# Altium schematic sheet Sheet1.SchDoc(Sheet1)
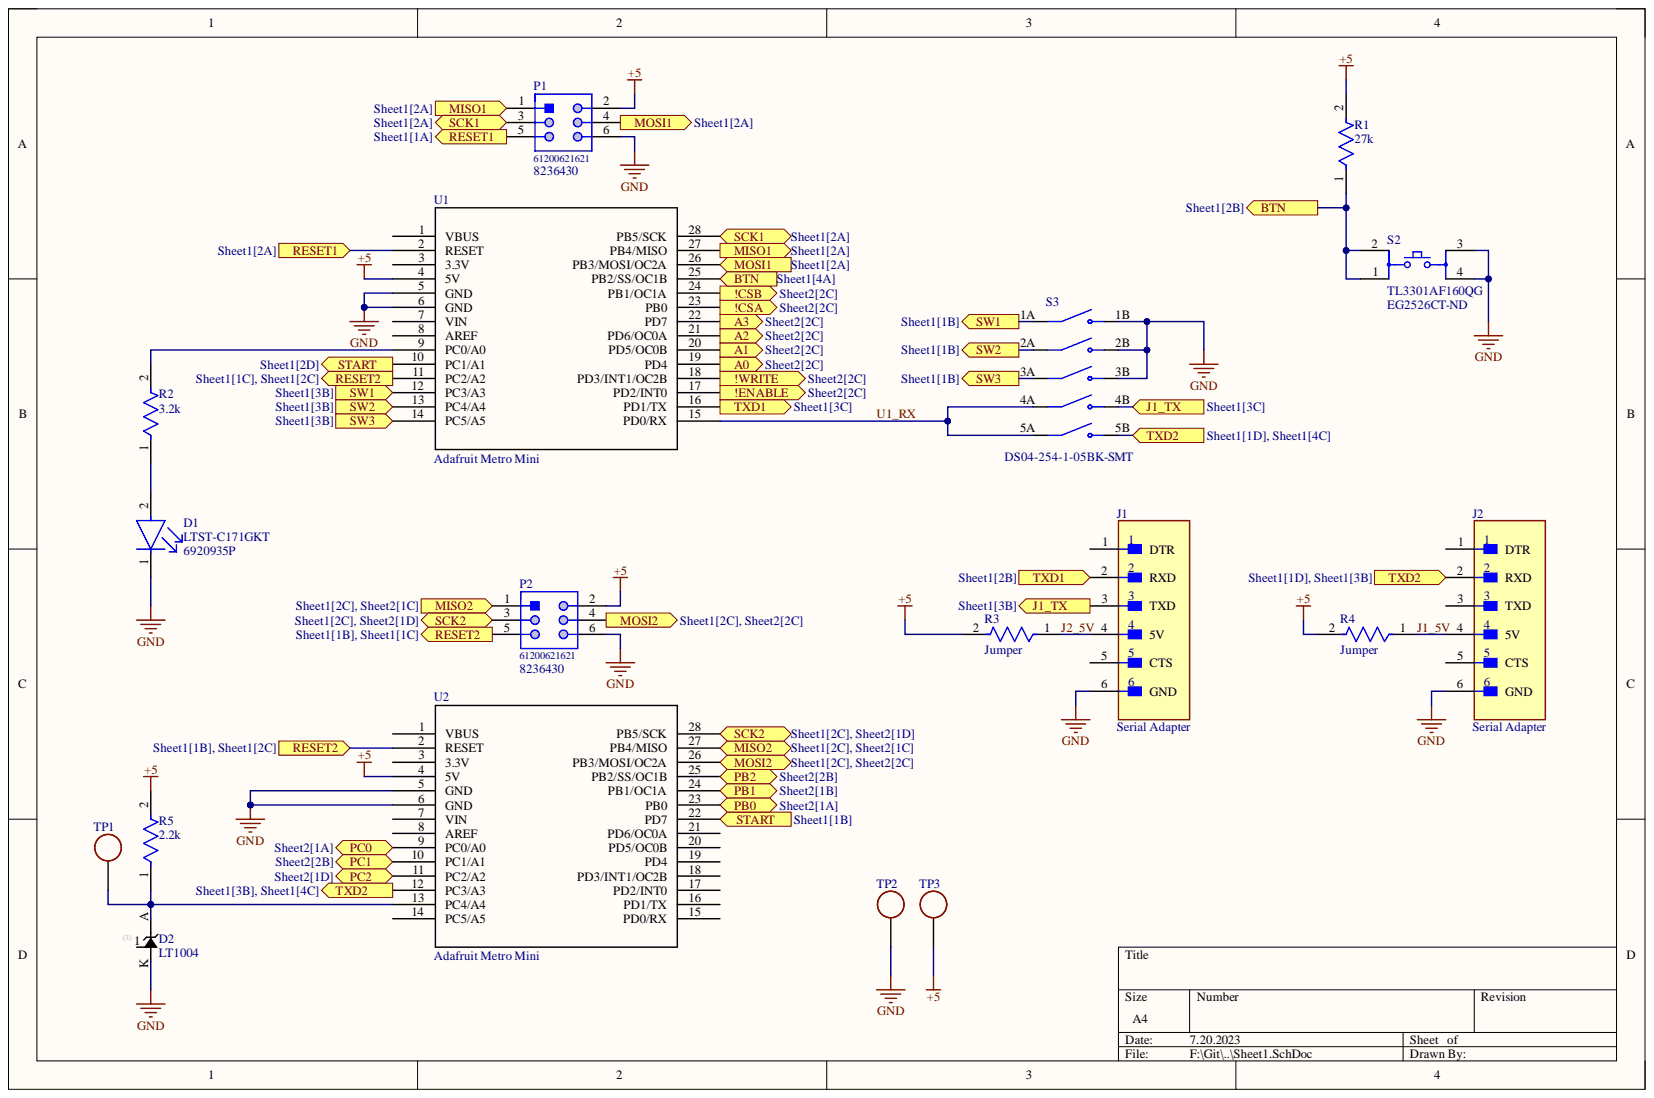
[diagram p0: Sheet1.SchDoc]

## Parts
D1  LTST-C171GKT  Supplier Part Number 1: 6920935P  [FP-LTST-C171GKT-MFG]
D2  LT1004  [LT1004CZ]
J1  Serial Adapter  [FP-22-28-4060-MFG]
J2  Serial Adapter  [FP-22-28-4060-MFG]
P1  61200621621  Supplier Part Number 1: 8236430  [61200621621]
P2  61200621621  Supplier Part Number 1: 8236430  [61200621621]
R1  27k  [FP-RMCF0805-IPC_B]
R2  3.2k  [FP-RMCF0805-IPC_B]
R3  Jumper  [FP-RMCF0805-IPC_B]
R4  Jumper  [FP-RMCF0805-IPC_B]
R5  2.2k  [FP-RMCF0805-IPC_B]
S1  TL3301AF160QG  [FP-TL3301AF160QG-MFG]
S2  TL3301AF160QG  Supplier Part Number 1: EG2526CT-ND  [FP-TL3301AF160QG-MFG]
S3  DS04-254-1-05BK-SMT  [SW_DS04-254-1-05BK-SMT]
TP1  61300111121  [61300111121]  (value not drawn)
TP2  61300111121  [61300111121]  (value not drawn)
TP3  61300111121  [61300111121]  (value not drawn)
U1  Adafruit Metro Mini  [Adafruit Metro Mini]  (no BOM)
U2  Adafruit Metro Mini  [Adafruit Metro Mini]  (no BOM)

## Ports
!CSA, !CSB, !ENABLE, !WRITE, A0, A1, A2, A3, BTN, J1_TX, MISO1, MISO2, MOSI1, MOSI2, PB0, PB1, PB2, PC0, PC1, PC2, RESET1, RESET2, SCK1, SCK2, START, SW1, SW2, SW3, TXD1, TXD2

## Nets
+5: P1.2 P2.2 R1.2 R3.2 R4.2 R5.2 TP3.1 U1.4(5V) U2.4(5V)
GND: D1.1 D2.K J1.6 J2.6 P1.6 P2.6 S2.3 S2.4 S3.1B S3.2B S3.3B TP2.1 U1.5(GND) U1.6(GND) U2.5(GND) U2.6(GND)
!CSA: U1.23(PB0) ; port !CSA
!CSB: U1.24(PB1/OC1A) ; port !CSB
!ENABLE: U1.17(PD2/INT0) ; port !ENABLE
!WRITE: U1.18(PD3/INT1/OC2B) ; port !WRITE
A0: U1.19(PD4) ; port A0
A1: U1.20(PD5/OC0B) ; port A1
A2: U1.21(PD6/OC0A) ; port A2
A3: U1.22(PD7) ; port A3
BTN: R1.1 S2.1 S2.2 U1.25(PB2/SS/OC1B) ; port BTN
J1_5V: J2.4 R4.1
J1_TX: J1.3 S3.4B ; port J1_TX
J2_5V: J1.4 R3.1
MISO1: P1.1 U1.27(PB4/MISO) ; port MISO1
MISO2: P2.1 U2.27(PB4/MISO) ; port MISO2
MOSI1: P1.4 U1.26(PB3/MOSI/OC2A) ; port MOSI1
MOSI2: P2.4 U2.26(PB3/MOSI/OC2A) ; port MOSI2
PB0: U2.23(PB0) ; port PB0
PB1: U2.24(PB1/OC1A) ; port PB1
PB2: U2.25(PB2/SS/OC1B) ; port PB2
PC0: U2.9(PC0/A0) ; port PC0
PC1: U2.10(PC1/A1) ; port PC1
PC2: U2.11(PC2/A2) ; port PC2
RESET1: P1.5 U1.2(RESET) ; port RESET1
RESET2: P2.5 U1.11(PC2/A2) U2.2(RESET) ; port RESET2
SCK1: P1.3 U1.28(PB5/SCK) ; port SCK1
SCK2: P2.3 U2.28(PB5/SCK) ; port SCK2
START: U1.10(PC1/A1) U2.22(PD7) ; port START
SW1: S3.1A U1.12(PC3/A3) ; port SW1
SW2: S3.2A U1.13(PC4/A4) ; port SW2
SW3: S3.3A U1.14(PC5/A5) ; port SW3
TXD1: J1.2 U1.16(PD1/TX) ; port TXD1
TXD2: J2.2 S3.5B U2.12(PC3/A3) ; port TXD2
U1_RX: S3.4A S3.5A U1.15(PD0/RX)
NetD1_2: D1.2 R2.1
NetD2_A: D2.A R5.1 TP1.1 U2.13(PC4/A4)
NetR2_2: R2.2 U1.9(PC0/A0)

Not connected: D2 3; J1 1 5; J2 1 3 5; S1 1 2 3 4; U1 1(VBUS) 3(3.3V) 7(VIN) 8(AREF); U2 1(VBUS) 3(3.3V) 7(VIN) 8(AREF) 14(PC5/A5) 15(PD0/RX) 16(PD1/TX) 17(PD2/INT0) 18(PD3/INT1/OC2B) 19(PD4) 20(PD5/OC0B) 21(PD6/OC0A)

## Library data of the parts
D1 = CMP-22017-000007-1: LED GREEN CLEAR CHIP SMD; Dominant Wavelength: 569nm; Forward Current: 30mA; Forward Voltage: 2.1V; Lens Color: Colorless; Lens Style: Diffused; Luminous Intensity: 12mcd; Number of Elements: 1; Power Dissipation: 100mW; Reverse Voltage: 5V; Test Current: 10mA; Viewing Angle: 130°; Wavelength: 585nm; Case Code (Imperial): 0805; Case Code (Metric): 2012; Case/Package: 0805; Color: Green; Component Kind: Standard; Depth: 1.25mm; Height: 0.8mm; Illumination Color: Green; Lead Free: Lead Free; Length: 2mm; Lens Transparency: Clear; Max Operating Temperature: 85°C; Min Operating Temperature: -55°C; Mount: Surface Mount; Number of LEDs: 1; Number of Pins: 2; Package Quantity: 1; Packaging: Tape and Reel; Power Rating: 100mW; Radiation Hardening: No; Supplier 1: Rs; Width: 1.25mm; Library Name: DualMetro.SchLib
J1 J2 = CMP-04776-000763-1: CONN HEADER VERT 6POS 2.54MM; Connector Type: Male Pin; Contact Resistance: 20mR; Current Rating: 4A; Gender: Male; Max Voltage Rating (AC): 250V; Max Voltage Rating (DC): 250.25kV; Number of Contacts: 6; Color: Black; Component Kind: Standard; Contact Material: Brass; Contact Mating Length: 0.24inch; Contact Plating: Tin; Contact Type: Male Pin; Depth: 2.49mm; Housing Color: Black; Housing Material: Thermoplastic; Length: 15.24mm; Max Operating Temperature: 75°C; Min Operating Temperature: 0°C; Mount: Through Hole; Number of Positions: 6; Number of Rows: 1; Orientation: Straight; Package Quantity: 1; Packaging: Bulk; Pitch: 2.54mm; RoHS Compliant: Yes; Series: KK® 42375; Termination: Solder; Voltage Rating: 250V; Library Name: DualMetro.SchLib
P1 P2 = CMP-1502-00000-1: Male Box Header WR-BHD, THT, Vertical, pitch 2.54 mm, 6 pins; Manufacturer: Wurth Elektronik; Contact Resistance: 20mOhm; Pin Number: 6; Pitch: 2.54mm; Withstanding Voltage: 500VAC; Working Voltage: 250VAC; Component Kind: Standard; Datasheet URL: https://katalog.we-online.de/em/datasheet/6120xx21621.pdf; Gender: Male; Manufacturer URL: http://www.we-online.de; Mounting Technology: THT; Operating Temperature: -40to125degC; Orientation: Vertical; Package Reference: 61200621621; Packaging: Tray; Part Number: 61200621621; Published Date: August2018; Supplier 1: Rs; Library Name: DualMetro.SchLib; Publisher: WurthElektronik
R1-R5 = CMP-26527-000017-1: RES 0 OHM JUMPER 1/8W 0805; Max Power Dissipation: 125mW; Resistance: 0mR; Case Code (Imperial): 0805; Case Code (Metric): 2012; Case/Package: 0805; Component Kind: Standard; Composition: Thick Film; Contact Plating: Tin; Depth: 1.25mm; Features: Automotive AEC-Q200; Height: 0.026inch; Lead Free: Lead Free; Length: 2mm; Max Operating Temperature: 155°C; Military Standard: Not; Min Operating Temperature: -55°C; Mount: Surface Mount; Number of Terminations: 2; Packaging: Tape and Reel; Power Rating: 125mW; Radiation Hardening: No; Width: 0.049inch; Library Name: DualMetro.SchLib
S1 S2 = TL3301AF160QG: Keypad Switch, 1 Switches, SPST, Momentary-tactile, 0.05A, 50VDC, 2.06N, Solder Terminal, Surface Mount-straight12V; Actuator Length: 1.4mm; Actuator Material: Nylon; Actuator Type: Standard; Contact Resistance: 100mR; Current Rating: 50mA; Electrical Life: 100000Cycles; Illumination Type: Non-Illuminated; Max Voltage Rating (DC): 50V; Operating Force: 160gf; Switch Function: Off-Mom; Switch Travel: 0.25mm; Voltage Rating (DC): 12V; Component Kind: Standard; Contact Material: Silver; Contact Plating: Silver; Insulation Resistance: 100MR; Lead Free: Lead Free; Max Operating Temperature: 70°C; Mechanical Life: 100000Cycles; Min Operating Temperature: -20°C; Mount: Surface Mount; Orientation: Straight; Package Quantity: 1000; Packaging: Tape and Reel; REACH SVHC: No SVHC; RoHS Compliant: Yes; Series: TL3301; Supplier 1: Digi-Key; Termination: Gull Wing; Throw Configuration: SPST; Voltage Rating: 50V; Library Name: DualMetro.SchLib
  S1: Supplier Part Number 1: EG2526CT-ND
TP1-TP3 = Testpoint: THT Vertical Pin Header WR-PHD, Pitch 2.54 mm, Single Row, 1 pins; Manufacturer: Wurth Elektronik; Contact Resistance: 20mOhm; Gender: Male; Pin Number: 1; Pitch: 2.54mm; Rated Current: 3A; Withstanding Voltage: 500VAC; Working Voltage: 250VAC; Component Kind: Standard; Datasheet URL: https://katalog.we-online.de/em/datasheet/6130xx11121.pdf; Manufacturer URL: http://www.we-online.de; Mounting Technology: THT; Operating Temperature: -40to105degC; Orientation: Vertical; Package Reference: 61300111121; Packaging: Bag; Part Number: 61300111121; Row Number: Single; Library Name: DualMetro.SchLib; Publisher: WurthElektronik
D2 = LT1004: Component Kind: Standard; Library Name: DualMetro.SchLib
S3 = DS04-254-1-05BK-SMT: Availability: Unavailable; Component Kind: Standard; MP: DS04-254-1-05BK-SMT; Package: None; Price: None; Library Name: DualMetro.SchLib
U1 U2 = Adafruit Metro Mini: Library Name: DualMetro.SchLib
